# Altium schematic sheet 12 - Back Page.SchDoc("12 - Back Page")
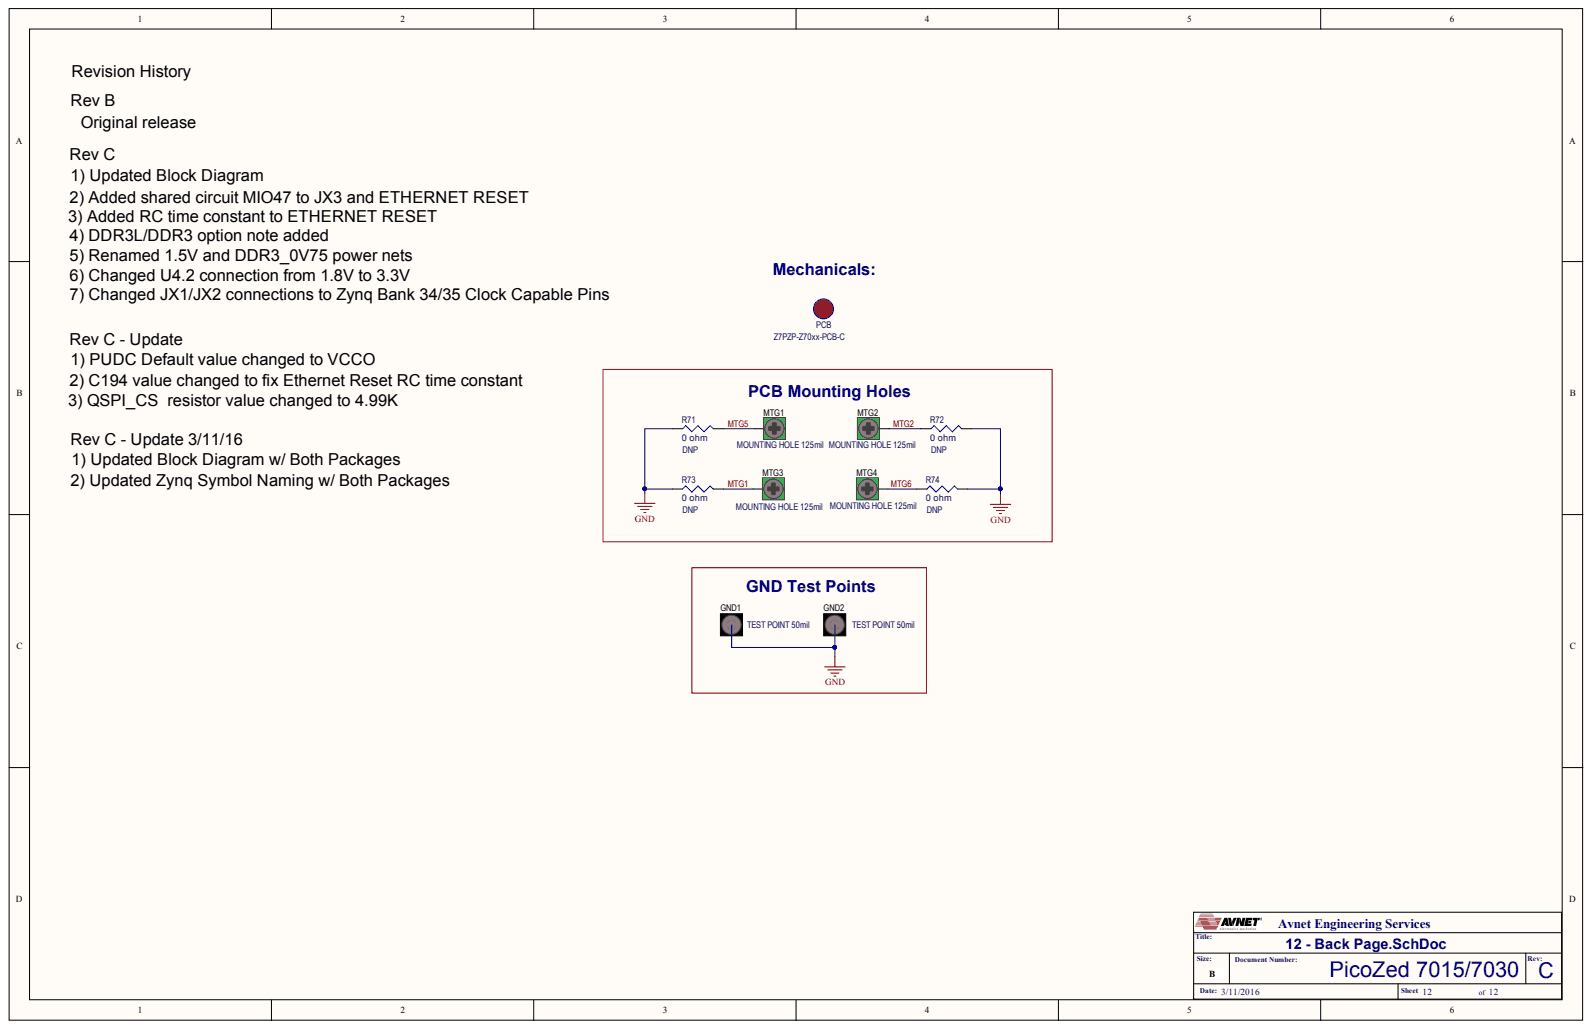
[diagram p0: 12 - Back Page.SchDoc]

## Parts
BPH1  PCB  MFGPN: Z7PZP-Z70xx-PCB-C  (mechanical)
GND1  TEST POINT 50mil  [TP-50]  (no BOM)
GND2  TEST POINT 50mil  [TP-50]  (no BOM)
MTG1  MOUNTING HOLE 125mil  [MTG-125]  (no BOM)
MTG2  MOUNTING HOLE 125mil  [MTG-125]  (no BOM)
MTG3  MOUNTING HOLE 125mil  [MTG-125]  (no BOM)
MTG4  MOUNTING HOLE 125mil  [MTG-125]  (no BOM)
R71  0 ohm  [R0402]
R72  0 ohm  [R0402]
R73  0 ohm  [R0402]
R74  0 ohm  [R0402]

## Nets
GND: GND1.0 GND2.0 R71.2 R72.1 R73.2 R74.1
MTG1: MTG3.0 R73.1
MTG2: MTG2.0 R72.2
MTG5: MTG1.0 R71.1
MTG6: MTG4.0 R74.2

## Library data of the parts
BPH1 = Dummy_Part: AVLNo: N/A; Description: PCB; Library Name: Spartan6_LXT_Dev.SchLib
GND1 GND2 = TEST_POINT_50mil: Test Point, 50mil; Category: Test Point; Library Name: new.SchLib; Status: Production
MTG1-MTG4 = MOUNTING_HOLE_125mil: Mounting Hole, 125mil; AES Part #: AVDNP-0000003; Category: Mounting-Hole; Library Name: new.SchLib; Status: Production
R71-R74 = AVPR-0000027: RES SM 0 ohm 62mW J R0402; PartCode: PR; AsyTxt: PR027; Database Table Name: Passive-Res$; MFG: Vishay; MFG Code: DAL; MFGPN: CRCW04020000Z0ED; Temp: I; AVLNo: AVPR-0000027; Component Kind: Standard; ComponentLink1Description: Datasheet; Device: RES; DNP: DNP; PkgType: SM; RoHS: Yes; Status: Preliminary; Value: 0 ohm; Watt: 62mW; ComponentLink1URL: C:\AVDBLIB\DATASHEETS\Dale_CRCW.pd; Library Name: Avnet_DBlib.DbLib
